# Altium schematic sheet connectors.SchDoc
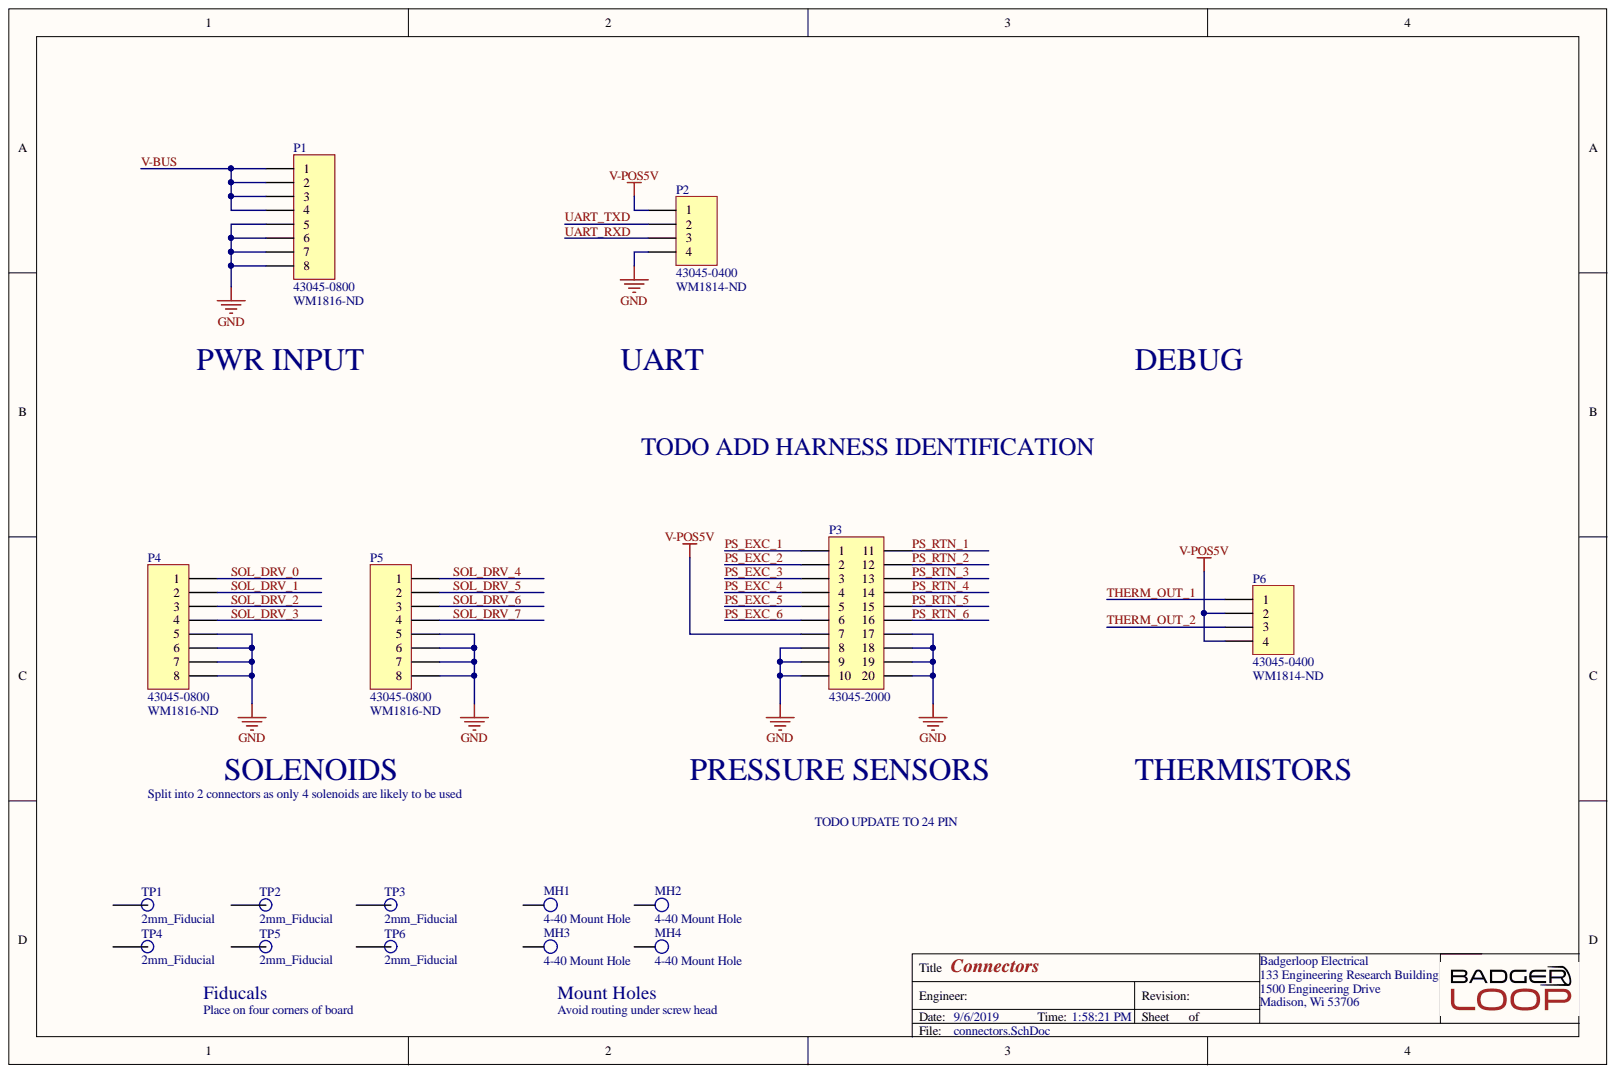
[diagram p0: connectors.SchDoc]

## Parts
MH1  4-40 Mount Hole  [MH]
MH2  4-40 Mount Hole  [MH]
MH3  4-40 Mount Hole  [MH]
MH4  4-40 Mount Hole  [MH]
P1  43045-0800  Supplier Part Number 1: WM1816-ND  [430450800]
P2  43045-0400  Supplier Part Number 1: WM1814-ND  [430450400]
P3  43045-2000  [430452000]
P4  43045-0800  Supplier Part Number 1: WM1816-ND  [430450800]
P5  43045-0800  Supplier Part Number 1: WM1816-ND  [430450800]
P6  43045-0400  Supplier Part Number 1: WM1814-ND  [430450400]
TP1  2mm_Fiducial  [2mm_Fiducial]
TP2  2mm_Fiducial  [2mm_Fiducial]
TP3  2mm_Fiducial  [2mm_Fiducial]
TP4  2mm_Fiducial  [2mm_Fiducial]
TP5  2mm_Fiducial  [2mm_Fiducial]
TP6  2mm_Fiducial  [2mm_Fiducial]

## Nets
GND: P1.5 P1.6 P1.7 P1.8 P2.4 P3.8 P3.9 P3.10 P3.17 P3.18 P3.19 P3.20 P4.5 P4.6 P4.7 P4.8 P5.5 P5.6 P5.7 P5.8
V-POS5V: P2.1 P3.7 P6.2 P6.4
PS_EXC_1: P3.1
PS_EXC_2: P3.2
PS_EXC_3: P3.3
PS_EXC_4: P3.4
PS_EXC_5: P3.5
PS_EXC_6: P3.6
PS_RTN_1: P3.11
PS_RTN_2: P3.12
PS_RTN_3: P3.13
PS_RTN_4: P3.14
PS_RTN_5: P3.15
PS_RTN_6: P3.16
SOL_DRV_0: P4.1
SOL_DRV_1: P4.2
SOL_DRV_2: P4.3
SOL_DRV_3: P4.4
SOL_DRV_4: P5.1
SOL_DRV_5: P5.2
SOL_DRV_6: P5.3
SOL_DRV_7: P5.4
THERM_OUT_1: P6.1
THERM_OUT_2: P6.3
UART_RXD: P2.3
UART_TXD: P2.2
V-BUS: P1.1 P1.2 P1.3 P1.4

Not connected: MH1 46; MH2 46; MH3 46; MH4 46; TP1 1; TP2 1; TP3 1; TP4 1; TP5 1; TP6 1

## Library data of the parts
P1 P4 P5 = 43045-0800: CONN HEADER R/A 8POS 3MM; Manufacturer: Molex; Approvals: UL,CSA,TUV; Contact Resistance: 10mR; Current Rating: 5A; Gender: Male; Glow Wire Compliant: Compliant; Insulation Resistance: 1GR; Max Voltage Rating (DC): 250.25kV; Number of Circuits: 8; Voltage Rating: 600V; Voltage Rating (AC): 250V; Case/Package: SMC; Color: Black; Component Kind: Standard; ComponentLink1Description: https://datasheet.ciiva.com/5158/0430450800-pcb-headers-142625-5158817.pdf?src-supplier=Mouser; ComponentLink1URL: https://datasheet.ciiva.com/5158/0430450800-pcb-headers-142625-5158817.pdf?src-supplier=Mouser; ComponentLink2Description: https://datasheet.ciiva.com/7683/27645-7683683.pdf?src-supplier=Element14; ComponentLink2URL: https://datasheet.ciiva.com/7683/27645-7683683.pdf?src-supplier=Element14; ComponentLink3Description: https://datasheet.ciiva.com/19462/883536-19462164.pdf?src-supplier=Jameco; ComponentLink3URL: https://datasheet.ciiva.com/19462/883536-19462164.pdf?src-supplier=Jameco; ComponentLink4Description: https://datasheet.ciiva.com/11751/0900766b80027348-11751142.pdf?src-supplier=RSComponents; ComponentLink4URL: https://datasheet.ciiva.com/11751/0900766b80027348-11751142.pdf?src-supplier=RSComponents; ComponentLink5Description: https://datasheet.ciiva.com/30198/267770430450800-pcb-headers-30198875.pdf?src-supplier=Onlinecomponents.com; ComponentLink5URL: https://datasheet.ciiva.com/30198/267770430450800-pcb-headers-30198875.pdf?src-supplier=Onlinecomponents.com; ComponentLink6Description: https://datasheet.ciiva.com/1443/1850016-1443537.pdf?src-supplier=Element14; ComponentLink6URL: https://datasheet.ciiva.com/1443/1850016-1443537.pdf?src-supplier=Element14; ComponentLink7Description: https://datasheet.ciiva.com/14155/04402310-14155206.pdf?src-supplier=Distrelec; ComponentLink7URL: https://datasheet.ciiva.com/14155/04402310-14155206.pdf?src-supplier=Distrelec; ComponentLink8Description: https://datasheet.ciiva.com/16657/0430450800-pcb-headers-16657964.pdf?src-supplier=Avnet+Europe; ComponentLink8URL: https://datasheet.ciiva.com/16657/0430450800-pcb-headers-16657964.pdf?src-supplier=Avnet+Europe; ComponentLink9Description: https://datasheet.ciiva.com/10087/0430450800-pcb-headers-10087853.pdf?src-supplier=Avnet; ComponentLink9URL: https://datasheet.ciiva.com/10087/0430450800-pcb-headers-10087853.pdf?src-supplier=Avnet; Contact Material: Brass,Tin; Contact Plating: Tin; Depth: 12.2mm; Diameter: 3mm; Flammability Rating: UL94 V-0; Halogen Free: Low Halogen; Height: 8.77mm; Housing Color: Black; Housing Material: Thermoplastic; Lead Free: Lead Free; Length: 16.15mm; Library Name: connector.SchLib; Manufacturer Part Number: 43045-0800; Material: Polyester; Max Current Rating: 5A; Max Operating Temperature: 105°C; Min Operating Temperature: -40°C; Mount: Through Hole; Number of Contacts: 8; Number of Poles: 8; Number of Positions: 8; Number of Rows: 2; Orientation: Right Angle; Package Quantity: 1440; Packaging: Tray; Pitch: 3mm; Pricing 1: 1=1.91000, 10=1.73100, 100=1.48390, 500=1.23656, 1000=1.05990, 5000=0.93978, 10000=0.91858 (USD); Radiation Hardening: No; REACH SVHC: Unknown; RoHS: Yes; Row Spacing: 3mm; Stock 1: 2632; Supplier 1: Digi-Key; Termination: Solder; Voltage Rating (DC): 250V; Working Voltage: 250V
P2 P6 = 43045-0400: Male connector dual row 90° 3 mm Pole no. 2x2 Micro-Fit, 43045-0400, Molex; Connector Type: Male Pin; Contact Resistance: 10mR; Max Voltage Rating (AC): 250V; Number of Contacts: 4; Pitch: 3mm; Row Spacing: 0.118inch; Color: Black; Component Kind: Standard; ComponentLink1Description: https://datasheet.ciiva.com/712/430450200-sd-712017.pdf?src-supplier=Digi-Key; ComponentLink1URL: https://datasheet.ciiva.com/712/430450200-sd-712017.pdf?src-supplier=Digi-Key; ComponentLink2Description: https://datasheet.ciiva.com/20209/22-3744e-20209522.pdf?src-supplier=Rapid+Electronics; ComponentLink2URL: https://datasheet.ciiva.com/20209/22-3744e-20209522.pdf?src-supplier=Rapid+Electronics; ComponentLink3Description: https://datasheet.ciiva.com/11746/0900766b80027348-11746988.pdf?src-supplier=RSComponents; ComponentLink3URL: https://datasheet.ciiva.com/11746/0900766b80027348-11746988.pdf?src-supplier=RSComponents; ComponentLink4Description: https://datasheet.ciiva.com/30193/145420430450400-pcb-headers-30193310.pdf?src-supplier=Onlinecomponents.com; ComponentLink4URL: https://datasheet.ciiva.com/30193/145420430450400-pcb-headers-30193310.pdf?src-supplier=Onlinecomponents.com; Contact Material: Brass; Contact Plating: Tin; Depth: 12.2mm; Fastening Type: Ramp; Flammability Rating: UL94 V-0; Housing Color: Black; Housing Material: Polymer; Length: 10.15mm; Library Name: connector.SchLib; Manufacturer: Molex; Manufacturer Part Number: 43045-0400; Max Operating Temperature: 105°C; Min Operating Temperature: -40°C; Mount: Through Hole; Number of Positions: 4; Number of Rows: 2; Orientation: Right Angle; Packaging: Tray; Pricing 1: 1=1.33000, 10=1.16700, 100=1.00670, 500=0.82368, 1000=0.73216, 5000=0.64064, 10000=0.60861 (USD); RoHS: Yes; Stock 1: 5904; Supplier 1: Digi-Key; Termination: Solder
P3 = 43045-2000: HEADER, RIGHT ANGLE, 20WAY; Manufacturer: Molex; Approvals: UL,CSA,TUV; Contact Resistance: 10mR; Current Rating: 5A; Gender: Male; Glow Wire Compliant: Compliant; Insulation Resistance: 1GR; Max Voltage Rating (DC): 250.25kV; Number of Circuits: 20; Operating Supply Voltage: 250V; Pitch: 3mm; Voltage Rating: 600V; Color: Black; Component Kind: Standard; ComponentLink1Description: https://datasheet.ciiva.com/5158/0430452000-pcb-headers-143029-5158912.pdf?src-supplier=Mouser; ComponentLink1URL: https://datasheet.ciiva.com/5158/0430452000-pcb-headers-143029-5158912.pdf?src-supplier=Mouser; ComponentLink2Description: https://datasheet.ciiva.com/30232/144790430452000-pcb-headers-30232337.pdf?src-supplier=Onlinecomponents.com; ComponentLink2URL: https://datasheet.ciiva.com/30232/144790430452000-pcb-headers-30232337.pdf?src-supplier=Onlinecomponents.com; ComponentLink3Description: https://datasheet.ciiva.com/11747/0900766b80d6135b-11747452.pdf?src-supplier=RSComponents; ComponentLink3URL: https://datasheet.ciiva.com/11747/0900766b80d6135b-11747452.pdf?src-supplier=RSComponents; ComponentLink4Description: https://datasheet.ciiva.com/19461/800067-19461036.pdf?src-supplier=Jameco; ComponentLink4URL: https://datasheet.ciiva.com/19461/800067-19461036.pdf?src-supplier=Jameco; ComponentLink5Description: https://datasheet.ciiva.com/1443/1786399-1443623.pdf?src-supplier=Element14; ComponentLink5URL: https://datasheet.ciiva.com/1443/1786399-1443623.pdf?src-supplier=Element14; ComponentLink6Description: https://datasheet.ciiva.com/10076/0430452000-pcb-headers-10076859.pdf?src-supplier=Avnet; ComponentLink6URL: https://datasheet.ciiva.com/10076/0430452000-pcb-headers-10076859.pdf?src-supplier=Avnet; ComponentLink7Description: https://datasheet.ciiva.com/14155/04402310-14155212.pdf?src-supplier=Distrelec; ComponentLink7URL: https://datasheet.ciiva.com/14155/04402310-14155212.pdf?src-supplier=Distrelec; Contact Material: Brass,Tin; Contact Plating: Tin; Depth: 12.2mm; Flammability Rating: UL94 V-0; Halogen Free: Low Halogen; Height: 8.77mm; Housing Color: Black; Housing Material: Thermoplastic; Lead Free: Lead Free; Lead Pitch: 3mm; Length: 34.15mm; Library Name: connector.SchLib; Manufacturer Part Number: 43045-2000; Material: Polyester; Max Current Rating: 5A; Max Operating Temperature: 105°C; Min Operating Temperature: -40°C; Mount: Through Hole; Number of Contacts: 20; Number of Poles: 20; Number of Positions: 20; Number of Rows: 2; Orientation: Right Angle; Package Quantity: 648; Packaging: Tray; Pricing 1: 1=5.17000, 10=4.95900, 100=4.13220, 500=3.51238, 1000=2.99585 (USD); Radiation Hardening: No; RoHS: Yes; Row Spacing: 3mm; Stock 1: 1197; Supplier 1: Digi-Key; Supplier Part Number 1: WM4726-ND; Termination: Solder; Voltage Rating (AC): 250V; Voltage Rating (DC): 250V; Working Voltage: 250V
MH1-MH4 = 4-40 Mount Hole: Component Kind: Standard; Library Name: misc.SchLib
TP1-TP6 = 2mm_Fiducial: Component Kind: Standard; Library Name: misc.SchLib
